# Altium schematic sheet Scheme.SchDoc
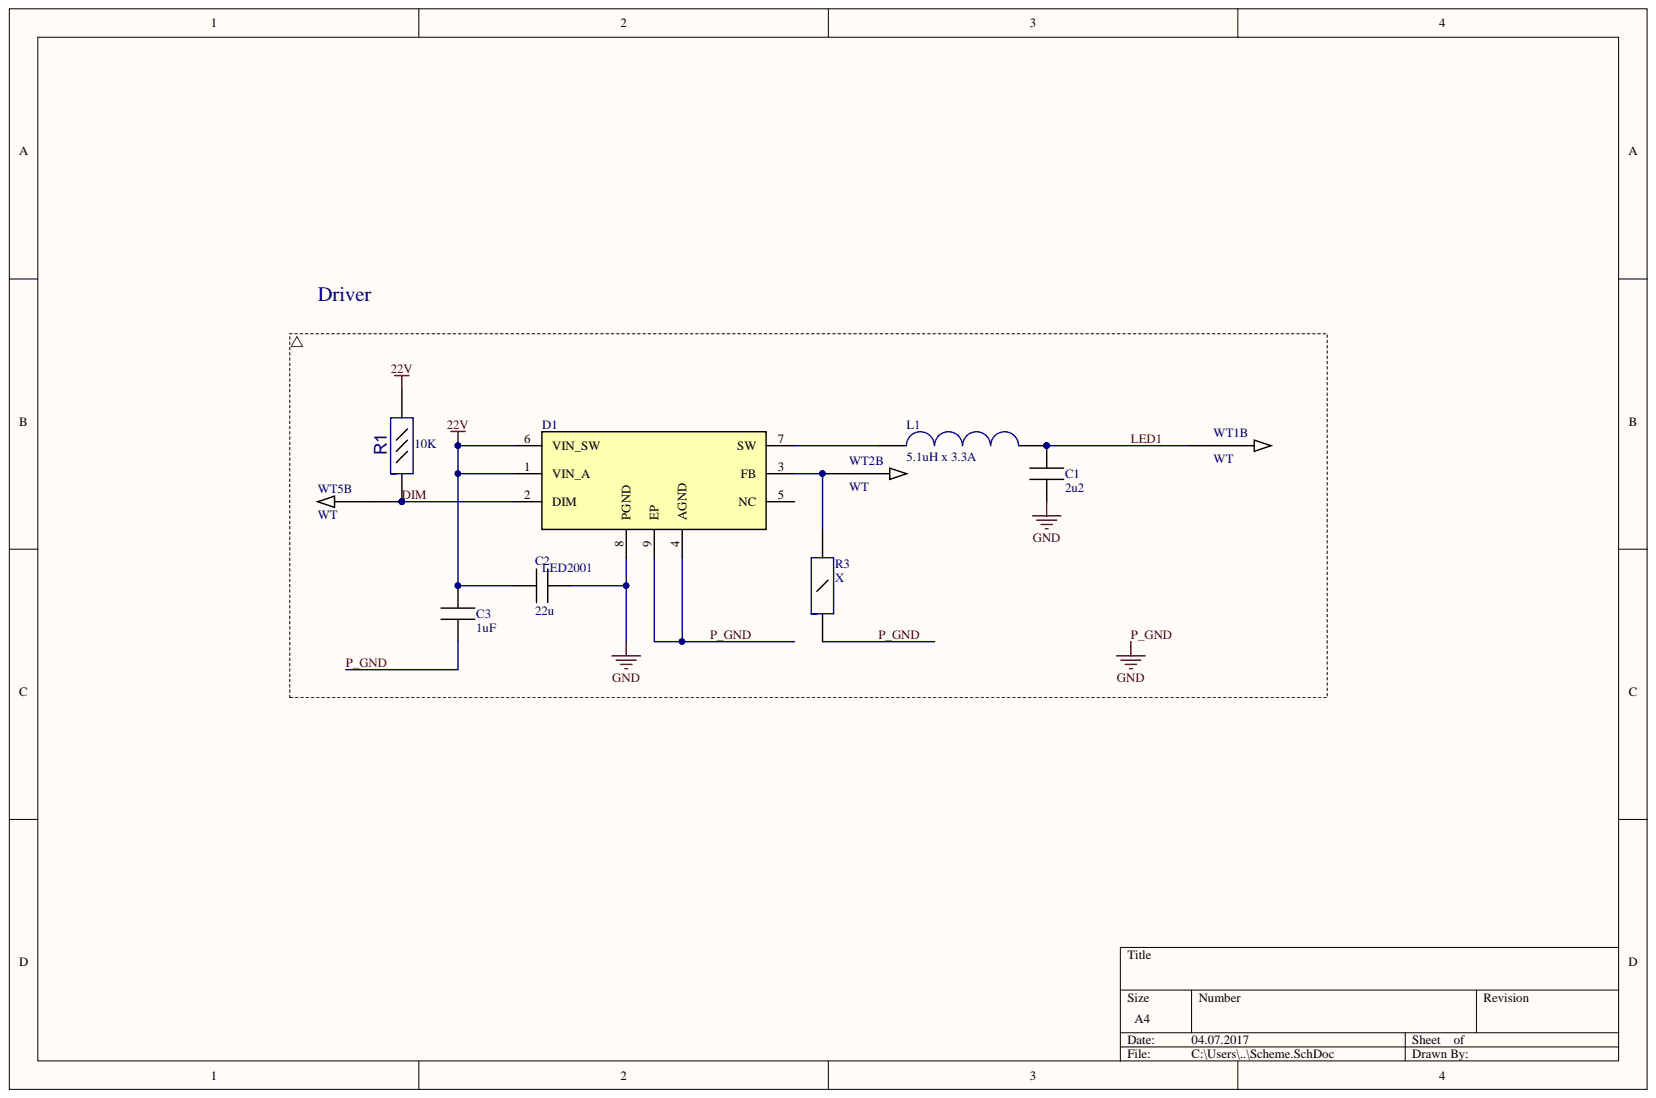
[diagram p0: Scheme.SchDoc]

## Parts
C1  2u2  [Cap 0603]
C2  22u  [Cap 1206]
C3  value 1uF  [Cap 0603]  (hidden comment: 1u0)
D1  LED2001  [HSOP-8 lite]
L1  51  value 5.1uH x 3.3A  [ASPI-6045S-5R1N-T]
R1  value 10K  [R0603 5%]  (hidden comment: 103)
R3  X  [Resistor 1206]
WT1  value WT  [WT]  (part B)
WT2  value WT  [WT]  (part B)
WT5  value WT  [WT]  (part B)

## Nets
22V: C2.1 C3.2 D1.1(VIN_A) D1.6(VIN_SW) R1.2
DIM: D1.2(DIM) R1.1 WT5.1
LED1: C1.2 L1.2 WT1.1
P_GND: C1.1 C2.2 C3.1 D1.4(AGND) D1.8(PGND) D1.9(EP) R3.1
NetD1_3: D1.3(FB) R3.2 WT2.1
NetD1_7: D1.7(SW) L1.1

Not connected: D1 5(NC)

## Library data of the parts
C1 = CMP-00038-2: C 2.2uF  x 25V; Component Name: Ceramic Capacitor, SMD, 0603, X7R, 2.2uF  x 25V 10%; ID: CCSM20225120; Найменування компонента: Конденсатор керамический, SMD, 0603, X7R, 2.2uF  x 25V 10%; Component Kind: Standard; Library Name: Altium Vault; Marking: 2u2; Package: 0603; Value: 2u2; Voltage: 25V
C2 = CMP-00564-1: C 22uF x 25V; Component Name: Ceramic Capacitor, SMD, 1206, X7R, 22uF x 25V; Найменування компонента: Конденсатор керамический, SMD, 1206, X7R, 22uF x 25V; Component Kind: Standard; Library Name: Altium Vault; Marking: 22u; Package: 1206; Value: 22u; Voltage: 25V
C3 = CMP-00074-2: C 1uF  x 25V; Component Name: Ceramic Capacitor, SMD, 0603, X7R, 1uF  x 25V 10%; ID: CCSM20105120; Найменування компонента: Конденсатор керамический, SMD, 0603, X7R, 1uF  x 25V 10%; Component Kind: Standard; Library Name: Altium Vault; Marking: 1u0; Package: 0603; Voltage: 25V
D1 = CMP-00575-3: Component Name: IC, Step-down DC-DC converter , LED2001, HSOP-8; Найменування компонента: Микросхема,  LED2001, HSOP-8; Component Kind: Standard; ComponentLink1Description: Datasheet; ComponentLink1URL: http://www.st.com/content/ccc/resource/technical/document/datasheet/37/ed/f7/77/d7/ed/4e/84/DM00079085.pdf/files/DM00079085.pdf/jcr:content/translations/en.DM00079085.pdf; Description: LED2001; Library Name: Altium Vault; Marking: LED2001; Mounting type: SMD; Package: HSOP-8; Value: LED2001
L1 = CMP-00554-1: ASPI-6045S-5R1N-T, 5.1uH x 3.3A; Component Name: Power Inductor,  ASPI-6045S-5R1N-T, 5.1uH x 3.3A; Найменування компонента: Индуктивность,  ASPI-6045S-5R1N-T, 5.1uH x 3.3A; Component Kind: Standard; ComponentLink1Description: Datasheet; ComponentLink1URL: http://www.abracon.com/Magnetics/power/ASPI-6045S.pdf; Library Name: Altium Vault; Marking: 51; Mounting type: SMD; Package: 6x6mm
R1 = CMP-00052-2: R 10K; Component Name: Fixed resistor, SMD, 0603, 10K 5%; ID: RRSM20103300; Найменування компонента: Резистор, SMD, 0603, 10 KОм 5%; Component Kind: Standard; Library Name: Altium Vault; Marking: 103; Package: 0603
R3 = CMP-00516-2: R X; Component Name: Fixed resistor, SMD, 1206, X; Найменування компонента: Резистор, SMD, 1206, X; Component Kind: Standard; Library Name: Altium Vault; Marking: X; Package: 1206; Value: X
WT1 WT2 WT5 = CMP-00159-1: WT; Component Name: Connector, WT; Component Kind: Standard; ComponentLink1Description: Datasheet; Library Name: Altium Vault; Package: WT; PartId: 2
